# Altium schematic sheet ART_Industry_SCH.SchDoc
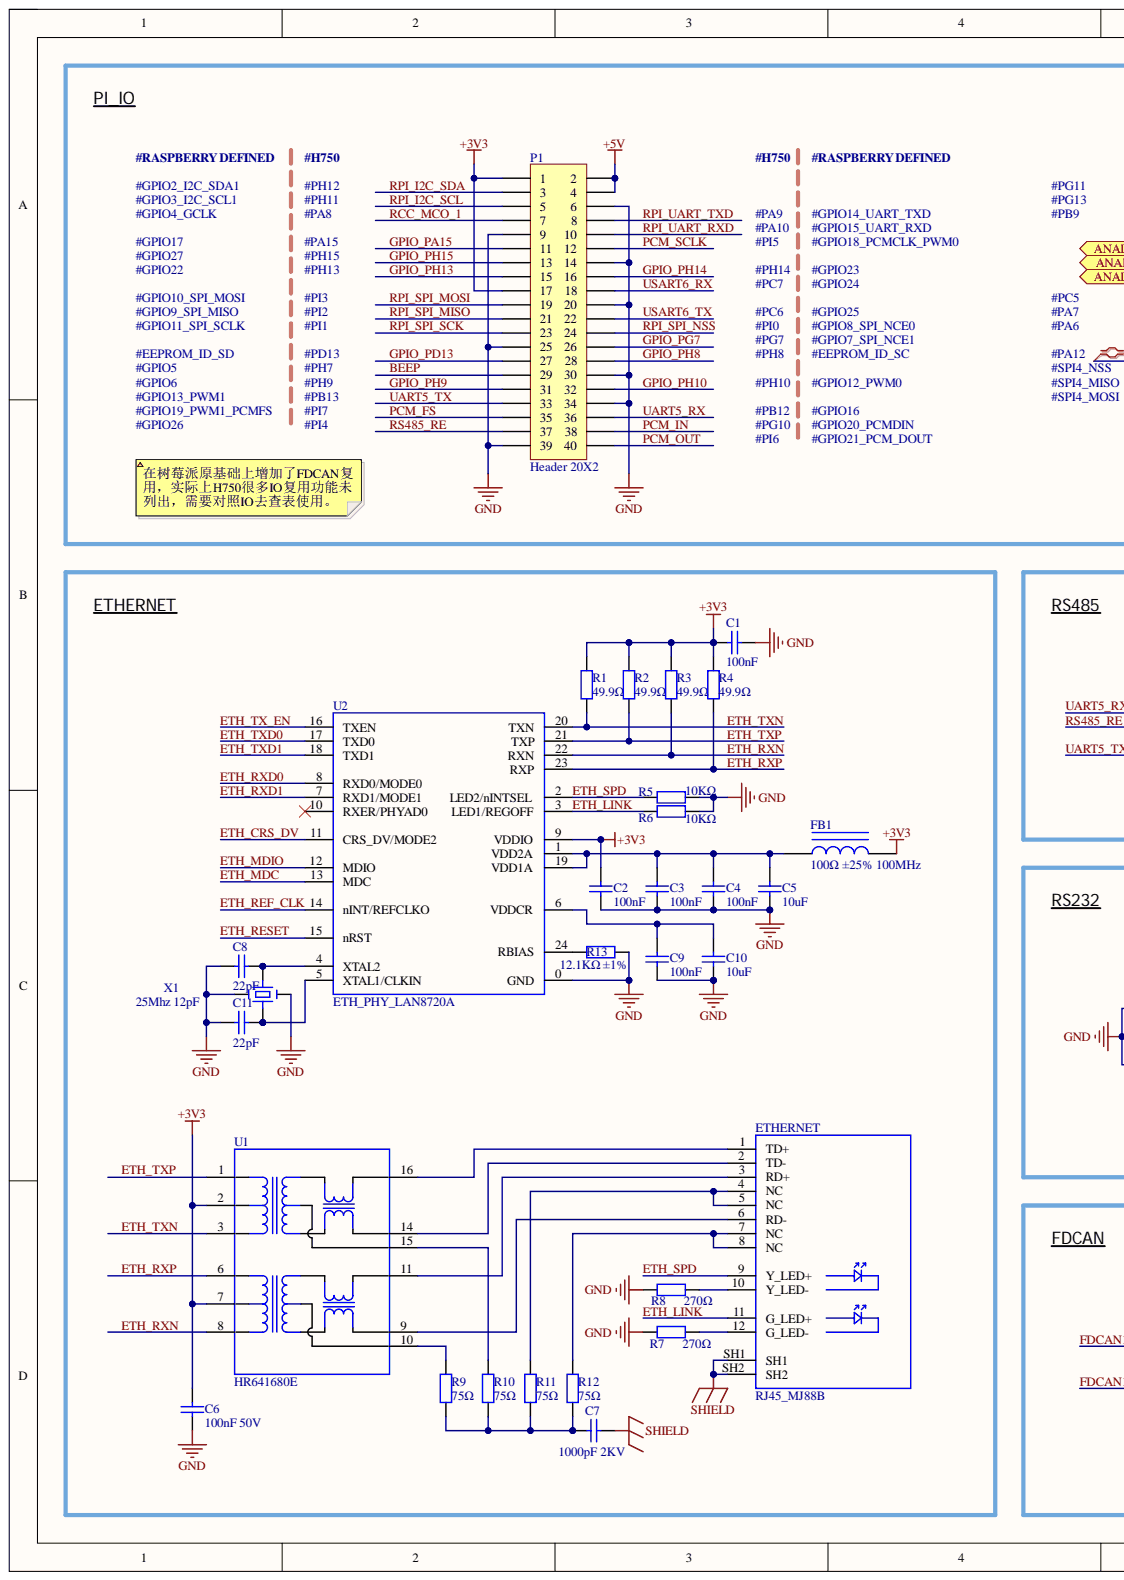
[diagram p0: ART_Industry_SCH.SchDoc, left]
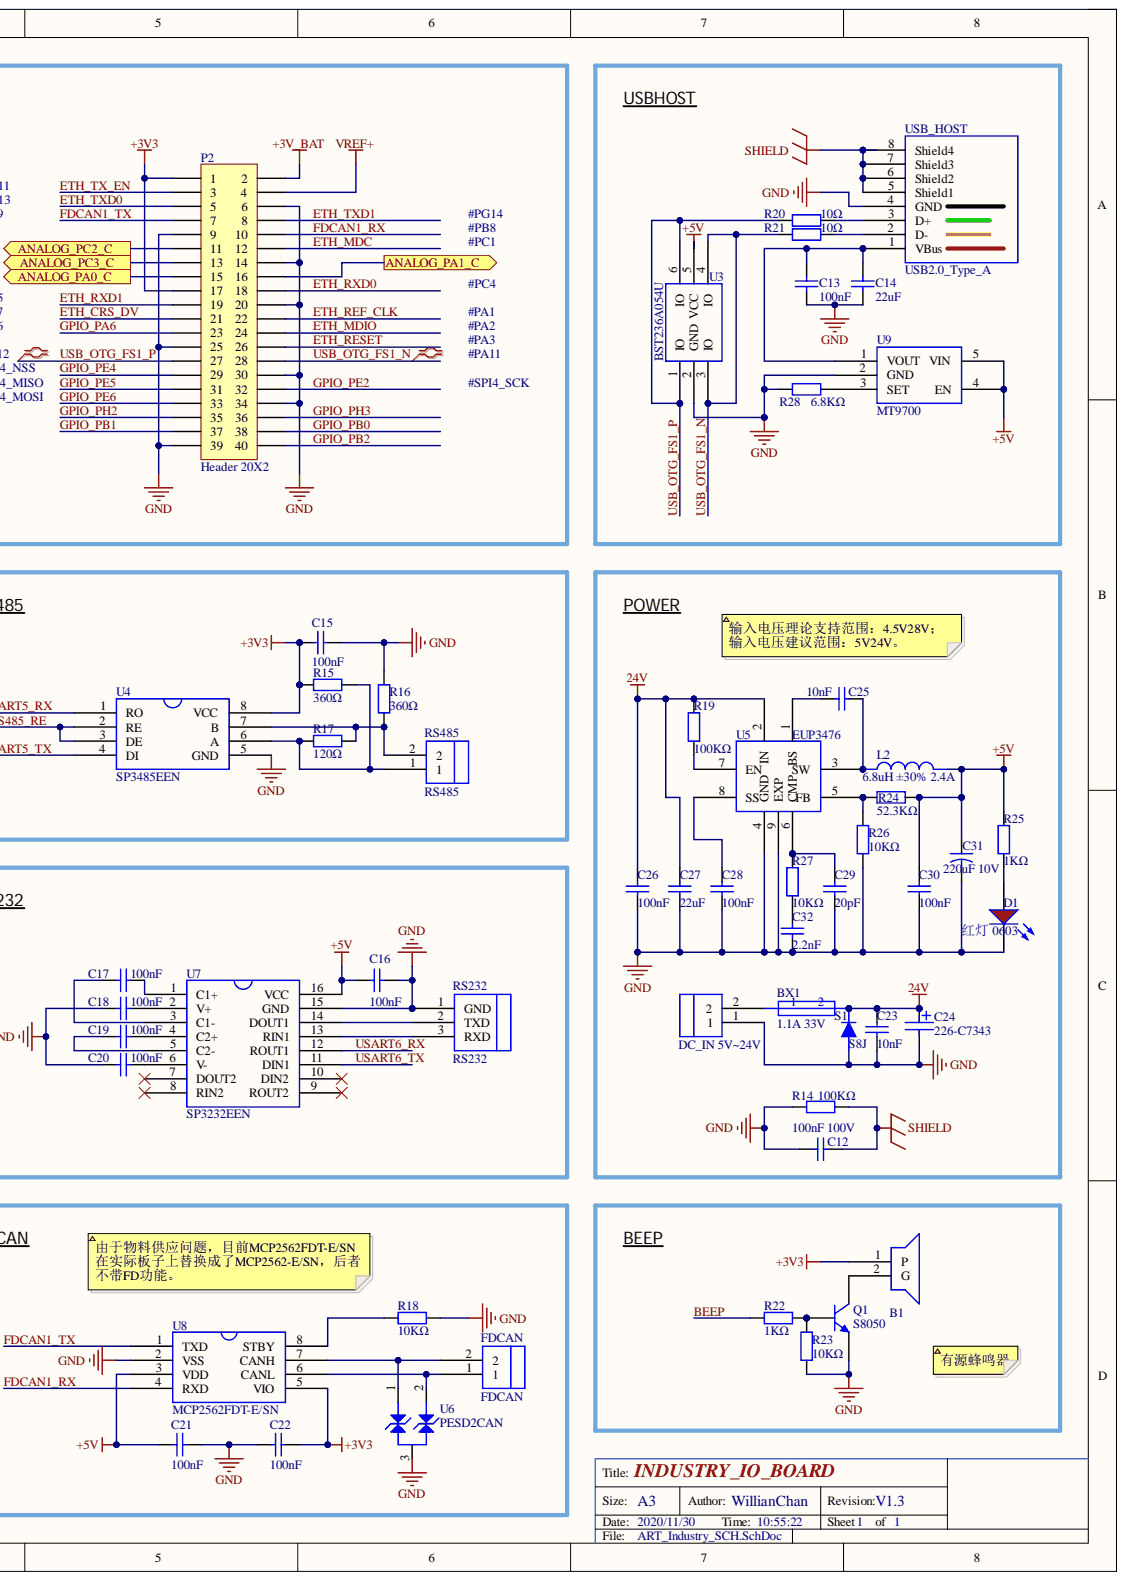
[diagram p1: ART_Industry_SCH.SchDoc, right]

## Parts
B1  BEEP  [BEEP]  (value not drawn)
BX1  1.1A 33V  [F1812]
C1  100nF  [0603_C]
C2  100nF  [0603_C]
C3  100nF  [0603_C]
C4  100nF  [0603_C]
C5  10uF  [0603_C]
C6  100nF 50V  [0603_C]
C7  1000pF 2KV  [1206_C]
C8  22pF  [0402_C]
C9  100nF  [0603_C]
C10  10uF  [0603_C]
C11  22pF  [0402_C]
C12  100nF 100V  [1206_C]
C13  100nF  [0603_C]
C14  22uF  [0603_C]
C15  100nF  [0603_C]
C16  100nF  [0603_C]
C17  100nF  [0603_C]
C18  100nF  [0603_C]
C19  100nF  [0603_C]
C20  100nF  [0603_C]
C21  100nF  [0603_C]
C22  100nF  [0603_C]
C23  10nF  [1206_C]
C24  226-C7343  [226-C7343]
C25  10nF  [0603_C]
C26  100nF  [0603_C]
C27  22uF  [0603_C]
C28  100nF  [0603_C]
C29  20pF  [0603_C]
C30  100nF  [0603_C]
C31  value 220uF 10V  [CAP-SMD_BD6.3-L6.6-W6.6-RD]
C32  2.2nF  [0603_C]
D1  红灯 0603  Package: 0603  [0603_LED_S1]
DC_IN_5V~24V  DC_IN  [CONN-TH_2P-P3.81_1727010]
ETHERNET  RJ45_MJ88B  [RJ45_WITH_LED_HALF]
FB1  100Ω ±25% 100MHz  [0603_L]
FDCAN  FDCAN  [CONN-TH_2P-P3.81_1727010]
L2  6.8uH ±30% 2.4A  [IND-SMD_L7.0-W7.0]
P1  Header 20X2  [HDR2X20]
P2  Header 20X2  [HDR2X20]
Q1  S8050  [SOT-23-3_L2.9-W1.3-P1.90-LS2.4-BR]
R1  49.9Ω  [0603_R]
R2  49.9Ω  [0603_R]
R3  49.9Ω  [0603_R]
R4  49.9Ω  [0603_R]
R5  10KΩ  [0603_R]
R6  10KΩ  [0603_R]
R7  270Ω  [0603_R]
R8  270Ω  [0603_R]
R9  75Ω  [0603_R]
R10  75Ω  [0603_R]
R11  75Ω  [0603_R]
R12  75Ω  [0603_R]
R13  12.1KΩ ±1%  [0603_R]
R14  100KΩ  [1206_R]
R15  360Ω  [0603_R]
R16  360Ω  [0603_R]
R17  120Ω  [0603_R]
R18  10KΩ  [0603_R]
R19  100KΩ  [0603_R]
R20  10Ω  [0603_R]
R21  10Ω  [0603_R]
R22  1KΩ  [0603_R]
R23  10KΩ  [0603_R]
R24  52.3KΩ  [0603_R]
R25  1KΩ  [0603_R]
R26  10KΩ  [0603_R]
R27  10KΩ  [0603_R]
R28  6.8KΩ  [0603_R]
RS232  RS232  [CONN-TH_3P-P3.81_1727023]
RS485  RS485  [CONN-TH_2P-P3.81_1727010]
S1  S8J  [S8J]
U1  HR641680E  [HR641680E]
U2  ETH_PHY_LAN8720A  [QFN-24_4x4x0.5]
U3  BST236A054U  [SOT-23-6_L2.9-W1.6-P0.95-LS2.8-BR]
U4  SP3485EEN  [MAX485]
U5  EUP3476  [EUP3476]
U6  value PESD2CAN  [SOT-23-3_L2.9-W1.3-P1.90-LS2.4-BR - duplicate]  (hidden comment: ESD-TRANSIL-DUAL-BIDIR)
U7  SP3232EEN  [MAX232]
U8  MCP2562FDT-E/SN  [MCP2562FDT-H/SN]
U9  MT9700  [SOT-23-5_L3.0-W1.7-P0.95-LS2.8-BR]
USB_HOST  USB2.0_Type_A  [USB-A-SMD_C41013]
X1  25Mhz 12pF  [SMD_2520]

## Ports
ANALOG_PA0_C, ANALOG_PA1_C, ANALOG_PC2_C, ANALOG_PC3_C

## Nets
24V: BX1.2 C23.2 C24.1 C26.2 C27.2 R19.2 S1.1 U5.2(IN)
+3V3: B1.1 C1.2 C2.2 C6.2 C15.2 C22.2 FB1.2 P1.1 P1.17 P2.1 P2.17 R1.2 R2.2 R3.2 R4.2 R15.1 U1.2 U1.7 U2.9(VDDIO) U4.8(VCC) U8.5(VIO)
+3V_BAT: P2.2
+5V: C16.1 C21.1 C30.2 C31.1 L2.2 P1.2 P1.4 R24.1 R25.2 U3.5(VCC) U7.16(VCC) U8.3(VDD) U9.4(EN) U9.5(VIN)
GND: C1.1 C2.1 C3.1 C4.1 C5.1 C6.1 C8.1 C9.1 C10.1 C11.1 C12.1 C13.1 C14.1 C15.1 C16.2 C18.1 C20.1 C21.2 C22.1 C23.1 C24.2 C26.1 C27.1 C28.1 C29.1 C30.1 C31.2 C32.1 D1.K DC_IN_5V~24V.1 P1.6 P1.9 P1.14 P1.20 P1.25 P1.30 P1.34 P1.39 P2.6 P2.9 P2.14 P2.20 P2.25 P2.30 P2.34 P2.39 Q1.2 R5.2 R6.2 R7.1 R8.1 R13.1 R14.1 R16.2 R18.2 R23.1 R26.1 R28.1 RS232.1 S1.2 U2.0(GND) U3.2(GND) U4.5(GND) U5.4(GND) U5.9(EXP) U6.3 U7.15(GND) U8.2(VSS) U9.2(GND) USB_HOST.4(GND) X1.2 X1.4
SHIELD: C7.2 C12.2 ETHERNET.SH1 ETHERNET.SH2 R14.2 USB_HOST.5(Shield1) USB_HOST.6(Shield2) USB_HOST.7(Shield3) USB_HOST.8(Shield4)
VREF+: P2.4
ANALOG_PA0_C: P2.15 ; port ANALOG_PA0_C
ANALOG_PA1_C: P2.16 ; port ANALOG_PA1_C
ANALOG_PC2_C: P2.11 ; port ANALOG_PC2_C
ANALOG_PC3_C: P2.13 ; port ANALOG_PC3_C
BEEP: P1.29 R22.1
ETH_CRS_DV: P2.21 U2.11(CRS_DV/MODE2)
ETH_LINK: ETHERNET.11(G_LED+) R6.1 U2.3(LED1/REGOFF)
ETH_MDC: P2.12 U2.13(MDC)
ETH_MDIO: P2.24 U2.12(MDIO)
ETH_REF_CLK: P2.22 U2.14(nINT/REFCLKO)
ETH_RESET: P2.26 U2.15(nRST)
ETH_RXD0: P2.18 U2.8(RXD0/MODE0)
ETH_RXD1: P2.19 U2.7(RXD1/MODE1)
ETH_RXN: R3.1 U1.8 U2.22(RXN)
ETH_RXP: R4.1 U1.6 U2.23(RXP)
ETH_SPD: ETHERNET.9(Y_LED+) R5.1 U2.2(LED2/nINTSEL)
ETH_TX_EN: P2.3 U2.16(TXEN)
ETH_TXD0: P2.5 U2.17(TXD0)
ETH_TXD1: P2.8 U2.18(TXD1)
ETH_TXN: R1.1 U1.3 U2.20(TXN)
ETH_TXP: R2.1 U1.1 U2.21(TXP)
FDCAN1_RX: P2.10 U8.4(RXD)
FDCAN1_TX: P2.7 U8.1(TXD)
GPIO_PA6: P2.23
GPIO_PA15: P1.11
GPIO_PB0: P2.38
GPIO_PB1: P2.37
GPIO_PB2: P2.40
GPIO_PD13: P1.27
GPIO_PE2: P2.32
GPIO_PE4: P2.29
GPIO_PE5: P2.31
GPIO_PE6: P2.33
GPIO_PG7: P1.26
GPIO_PH2: P2.35
GPIO_PH3: P2.36
GPIO_PH8: P1.28
GPIO_PH9: P1.31
GPIO_PH10: P1.32
GPIO_PH13: P1.15
GPIO_PH14: P1.16
GPIO_PH15: P1.13
PCM_FS: P1.35
PCM_IN: P1.38
PCM_OUT: P1.40
PCM_SCLK: P1.12
RCC_MCO_1: P1.7
RPI_I2C_SCL: P1.5
RPI_I2C_SDA: P1.3
RPI_SPI_MISO: P1.21
RPI_SPI_MOSI: P1.19
RPI_SPI_NSS: P1.24
RPI_SPI_SCK: P1.23
RPI_UART_RXD: P1.10
RPI_UART_TXD: P1.8
RS485_RE: P1.37 U4.2(RE) U4.3(DE)
UART5_RX: P1.36 U4.1(RO)
UART5_TX: P1.33 U4.4(DI)
USART6_RX: P1.18 U7.12(ROUT1)
USART6_TX: P1.22 U7.11(DIN1)
USB_OTG_FS1_N: P2.28 R21.1 U3.3(IO) U3.4(IO)
USB_OTG_FS1_P: P2.27 R20.1 U3.1(IO) U3.6(IO)
NetB1_2: B1.2 Q1.3
NetBX1_1: BX1.1 DC_IN_5V~24V.2
NetC3_2: C3.2 C4.2 C5.2 FB1.1 U2.1(VDD2A) U2.19(VDD1A)
NetC7_1: C7.1 R9.1 R10.1 R11.1 R12.1
NetC8_2: C8.2 U2.4(XTAL2) X1.1
NetC9_2: C9.2 C10.2 U2.6(VDDCR)
NetC11_2: C11.2 U2.5(XTAL1/CLKIN) X1.3
NetC13_2: C13.2 C14.2 U9.1(VOUT) USB_HOST.1(VBus)
NetC17_1: C17.1 U7.3(C1-)
NetC17_2: C17.2 U7.1(C1+)
NetC18_2: C18.2 U7.2(V+)
NetC19_1: C19.1 U7.5(C2-)
NetC19_2: C19.2 U7.4(C2+)
NetC20_2: C20.2 U7.6(V-)
NetC25_1: C25.1 U5.1(BS)
NetC25_2: C25.2 L2.1 U5.3(SW)
NetC28_2: C28.2 U5.8(SS)
NetC29_2: C29.2 R27.2 U5.6(CMP)
NetC32_2: C32.2 R27.1
NetD1_A: D1.A R25.1
NetETHERNET_1: ETHERNET.1(TD+) U1.16
NetETHERNET_2: ETHERNET.2(TD-) U1.14
NetETHERNET_3: ETHERNET.3(RD+) U1.11
NetETHERNET_4: ETHERNET.4(NC) ETHERNET.5(NC) R11.2
NetETHERNET_6: ETHERNET.6(RD-) U1.9
NetETHERNET_7: ETHERNET.7(NC) ETHERNET.8(NC) R12.2
NetETHERNET_10: ETHERNET.10(Y_LED-) R8.2
NetETHERNET_12: ETHERNET.12(G_LED-) R7.2
NetFDCAN_1: FDCAN.1 U6.2 U8.6(CANL)
NetFDCAN_2: FDCAN.2 U6.1 U8.7(CANH)
NetQ1_1: Q1.1 R22.2 R23.2
NetR9_2: R9.2 U1.10
NetR10_2: R10.2 U1.15
NetR13_2: R13.2 U2.24(RBIAS)
NetR15_2: R15.2 R17.1 RS485.1 U4.6(A)
NetR16_1: R16.1 R17.2 RS485.2 U4.7(B)
NetR18_1: R18.1 U8.8(STBY)
NetR19_1: R19.1 U5.7(EN)
NetR20_2: R20.2 USB_HOST.3(D+)
NetR21_2: R21.2 USB_HOST.2(D-)
NetR24_2: R24.2 R26.2 U5.5(FB)
NetR28_2: R28.2 U9.3(SET)
NetRS232_2: RS232.2 U7.14(DOUT1)
NetRS232_3: RS232.3 U7.13(RIN1)

Not connected: U2 10(RXER/PHYAD0); U7 7(DOUT2) 8(RIN2) 9(ROUT2) 10(DIN2)

## Library data of the parts
BX1 = F1812: Component Kind: Standard; Library Name: Industrial_Board_LIB.SchLib
C1-C4 C6 C9 C13 C15-C22 C26 C28 C30 = 0603 100nF (104) 10% 50V: 贴片电容; Component Kind: Standard; ComponentLink1Description: 供应商链接; ComponentLink1URL: http://www.szlcsc.com/product/details_15331.html; Library Name: Cap.SchLib; Package: 0603; Supplier: LC; SuppliersPartNumber: C14663
C5 C10 = 0603 10uF (106) 10% 10V: 贴片电容; Component Kind: Standard; ComponentLink1Description: 供应商链接; ComponentLink1URL: http://www.szlcsc.com/product/details_20411.html; Library Name: Cap.SchLib; Package: 0603; Supplier: LC; SuppliersPartNumber: C19702
C7 = 1206 470pF (471) 10% 50V: 贴片电容; Component Kind: Standard; ComponentLink1Description: 供应商链接; ComponentLink1URL: http://www.szlcsc.com/product/details_2220.html; Library Name: Cap.SchLib; Package: 1206; Supplier: LC; SuppliersPartNumber: C1868
C8 C11 = 0402 22pF (220) 5% 50V: 贴片电容; Component Kind: Standard; ComponentLink1Description: 供应商链接; ComponentLink1URL: http://www.szlcsc.com/product/details_1907.html; Library Name: Cap.SchLib; Package: 0402; Supplier: LC; SuppliersPartNumber: C1555
C12 = 1206 100nF (104) 10% 50V: 贴片电容; Component Kind: Standard; ComponentLink1Description: 供应商链接; ComponentLink1URL: http://www.szlcsc.com/product/details_25237.html; Library Name: Cap.SchLib; Package: 1206; Supplier: LC; SuppliersPartNumber: C24497
C14 C27 = 0603 22uF (226) 20% 6.3V: 贴片电容; Component Kind: Standard; ComponentLink1Description: 供应商链接; ComponentLink1URL: http://www.szlcsc.com/product/details_60514.html; Library Name: Cap.SchLib; Package: 0603; Supplier: LC; SuppliersPartNumber: C59461
C23 = 1206 10nF (103) 10% 50V: 贴片电容; Component Kind: Standard; ComponentLink1Description: 供应商链接; ComponentLink1URL: http://www.szlcsc.com/product/details_2198.html; Library Name: Cap.SchLib; Package: 1206; Supplier: LC; SuppliersPartNumber: C1846
C25 = 0603 10nF (103) 10% 50V: 贴片电容; Component Kind: Standard; ComponentLink1Description: 供应商链接; ComponentLink1URL: http://www.szlcsc.com/product/details_58143.html; Library Name: Cap.SchLib; Package: 0603; Supplier: LC; SuppliersPartNumber: C57112
C29 = 0603 20pF (200) 5% 50V: 贴片电容; Component Kind: Standard; ComponentLink1Description: 供应商链接; ComponentLink1URL: http://www.szlcsc.com/product/details_2000.html; Library Name: Cap.SchLib; Package: 0603; Supplier: LC; SuppliersPartNumber: C1648
C31 = Cap2: Capacitor; Component Kind: Standard; Library Name: Miscellaneous Devices.IntLib; PackageDescription: Capacitor; 2 Leads; PackageReference: CAPR5-4X5; Published: 23-Sep-2002; Signal Integrity: Cap; Simulation: CAP; Publisher: Altium Limited
C32 = 0603 2.2nF (222) 10% 50V: 贴片电容; Component Kind: Standard; ComponentLink1Description: 供应商链接; ComponentLink1URL: http://www.szlcsc.com/product/details_1956.html; Library Name: Cap.SchLib; Package: 0603; Supplier: LC; SuppliersPartNumber: C1604
D1 = 0603 红灯: 发光二极管; Component Kind: Standard; ComponentLink1Description: 供应商链接; ComponentLink1URL: http://www.szlcsc.com/product/details_2659.html; Library Name: LED.SchLib; Supplier: LC; SuppliersPartNumber: C2286
DC_IN_5V~24V FDCAN = RS485: Component Kind: Standard; Library Name: ART-H7_LIB.SchLib
ETHERNET = RJ45_MJ88B: ETH half height without internal isolation coil; Component Kind: Standard; Library Name: Industrial_Board_LIB.SchLib
FB1 = 0805 100Ω 25% 100MHz: 贴片磁珠; Component Kind: Standard; ComponentLink1Description: 供应商链接; ComponentLink1URL: http://www.szlcsc.com/product/details_1367.html; Library Name: IUD.SchLib; Package: 0805; Supplier: LC; SuppliersPartNumber: C1015
L2 = C207831: Component Kind: Standard; Library Name: ART_Industry_LIB.SchLib
P1 P2 = Header 20X2: Header, 20-Pin, Dual row; Component Kind: Standard; LatestRevisionDate: 17-Jul-2002; LatestRevisionNote: Re-released for DXP Platform.; Library Name: Miscellaneous Connectors.IntLib; Signal Integrity: Connector; Publisher: Altium Limited
Q1 = SOT-23 SS8050 Y1: 三极管; Component Kind: Standard; ComponentLink1Description: 供应商链接; ComponentLink1URL: http://www.szlcsc.com/product/details_2507.html; Library Name: Transistor_NPN.SchLib; Package: SOT-23; Supplier: LC; SuppliersPartNumber: C2150
R1-R4 = 0603 49.9Ω (49R9) 1%: 贴片电阻; Component Kind: Standard; ComponentLink1Description: 供应商链接; ComponentLink1URL: http://www.szlcsc.com/product/details_23912.html; Library Name: RES.SchLib; Package: 0603; Supplier: LC; SuppliersPartNumber: C23185
R5 R6 R18 R23 R26 R27 = 0603 10KΩ (1002) 1%: 贴片电阻; Component Kind: Standard; ComponentLink1Description: 供应商链接; ComponentLink1URL: http://www.szlcsc.com/product/details_26547.html; Library Name: RES.SchLib; Package: 0603; Supplier: LC; SuppliersPartNumber: C25804
R7 R8 = 0603 270Ω (2700) 1%: 贴片电阻; Component Kind: Standard; ComponentLink1Description: 供应商链接; ComponentLink1URL: http://www.szlcsc.com/product/details_23693.html; Library Name: RES.SchLib; Package: 0603; Supplier: LC; SuppliersPartNumber: C22966
R9-R12 = 0603 75Ω (75R0) 1%: 贴片电阻; Component Kind: Standard; ComponentLink1Description: 供应商链接; ComponentLink1URL: http://www.szlcsc.com/product/details_4682.html; Library Name: RES.SchLib; Package: 0603; Supplier: LC; SuppliersPartNumber: C4275
R13 = 0603 12KΩ (1202) 1%: 贴片电阻; Component Kind: Standard; ComponentLink1Description: 供应商链接; ComponentLink1URL: http://www.szlcsc.com/product/details_23517.html; Library Name: RES.SchLib; Package: 0603; Supplier: LC; SuppliersPartNumber: C22790
R14 = 1206 100KΩ (1003) 1%: 贴片电阻; Component Kind: Standard; ComponentLink1Description: 供应商链接; ComponentLink1URL: http://www.szlcsc.com/product/details_18588.html; Library Name: RES.SchLib; Package: 1206; Supplier: LC; SuppliersPartNumber: C17900
R15 R16 = 0603 360Ω (3600) 1%: 贴片电阻; Component Kind: Standard; ComponentLink1Description: 供应商链接; ComponentLink1URL: http://www.szlcsc.com/product/details_25937.html; Library Name: RES.SchLib; Package: 0603; Supplier: LC; SuppliersPartNumber: C25194
R17 = 0603 120Ω (1200) 1%: 贴片电阻; Component Kind: Standard; ComponentLink1Description: 供应商链接; ComponentLink1URL: http://www.szlcsc.com/product/details_23514.html; Library Name: RES.SchLib; Package: 0603; Supplier: LC; SuppliersPartNumber: C22787
R19 = 0603 100KΩ (1003) 1%: 贴片电阻; Component Kind: Standard; ComponentLink1Description: 供应商链接; ComponentLink1URL: http://www.szlcsc.com/product/details_26546.html; Library Name: RES.SchLib; Package: 0603; Supplier: LC; SuppliersPartNumber: C25803
R20 R21 = 0603 10Ω (10R0) 1%: 贴片电阻; Component Kind: Standard; ComponentLink1Description: 供应商链接; ComponentLink1URL: http://www.szlcsc.com/product/details_23586.html; Library Name: RES.SchLib; Package: 0603; Supplier: LC; SuppliersPartNumber: C22859
R22 R25 = 0603 1KΩ (1001) 1%: 贴片电阻; Component Kind: Standard; ComponentLink1Description: 供应商链接; ComponentLink1URL: http://www.szlcsc.com/product/details_21904.html; Library Name: RES.SchLib; Package: 0603; Supplier: LC; SuppliersPartNumber: C21190
R24 = 0603 750KΩ (7503) 1%: 贴片电阻; Component Kind: Standard; ComponentLink1Description: 供应商链接; ComponentLink1URL: http://www.szlcsc.com/product/details_23967.html; Library Name: RES.SchLib; Package: 0603; Supplier: LC; SuppliersPartNumber: C325624
R28 = 0603 6.8KΩ (6801) 1%: 贴片电阻; Component Kind: Standard; ComponentLink1Description: 供应商链接; ComponentLink1URL: http://www.szlcsc.com/product/details_23939.html; Library Name: RES.SchLib; Package: 0603; Supplier: LC; SuppliersPartNumber: C23212
U2 = LAN8720A: Component Kind: Standard; Library Name: ART-H7_LIB.SchLib
U4 = MAX485: Component Kind: Standard; Library Name: ART-H7_LIB.SchLib
U6 = MCD-01062: Automotive dual-line Transil, transient voltage suppressor (TVS) for CAN bus. Compliant with interfaces in automotive: CAN-FD, LIN, FlexRay, MOST, SENT, USB; Manufacturer: STMICROELECTRONICS; Manufacturer_Part_Number: ESDCAN02-2BWY; Part_Type: Diode; Database Table Name: MCD_PARTS$; Initial_Board_Reference: MB1331; Provided_By: Subcon; Component Kind: Standard; ComponentLink1Description: STM_ESD_ESDCAN02-2BWY; ComponentLink1URL: http://tunmcdsvn.tun.st.com/Component_Datasheet_Library/Trunk/Diodes/STM_ESD_ESDCAN02-2BWY; ECCN_EU: n/a; ECCN_US: n/a; Internal_Part_Number: MCD-01062; Library Name: MCD_PARTS_database.DbLib; Package: SOT323-3L; Status: Footprint available
U7 = MAX232: Component Kind: Standard; Library Name: ART-H7_LIB.SchLib
USB_HOST = USB2.0_TypeA: Component Kind: Standard; Library Name: ART_Industry_LIB.SchLib
X1 = Crystal: Component Kind: Standard; Library Name: ART-H7_LIB.SchLib
B1 = BEEP: Component Kind: Standard; Library Name: ART-H7_LIB.SchLib
C24 = 226-C7343: Component Kind: Standard; Library Name: ART-H7_LIB.SchLib
RS232 = RS232: Component Kind: Standard; Library Name: ART-H7_LIB.SchLib
RS485 = RS485: Component Kind: Standard; Library Name: ART-H7_LIB.SchLib
S1 = S8J: Component Kind: Standard; Library Name: ART-H7_LIB.SchLib
U1 = HR641680E: Component Kind: Standard; Library Name: ART-H7_LIB.SchLib
U3 = BST236A054U: Component Kind: Standard; Library Name: ART_Industry_LIB.SchLib
U5 = EUP3476: Component Kind: Standard; Library Name: ART-H7_LIB.SchLib
U8 = MCP2562FDT-E/SN: Component Kind: Standard; Library Name: ART_Industry_LIB.SchLib
U9 = MT9700: Component Kind: Standard; Library Name: ART_Industry_LIB.SchLib
